# Altium schematic sheet p02_power.SchDoc
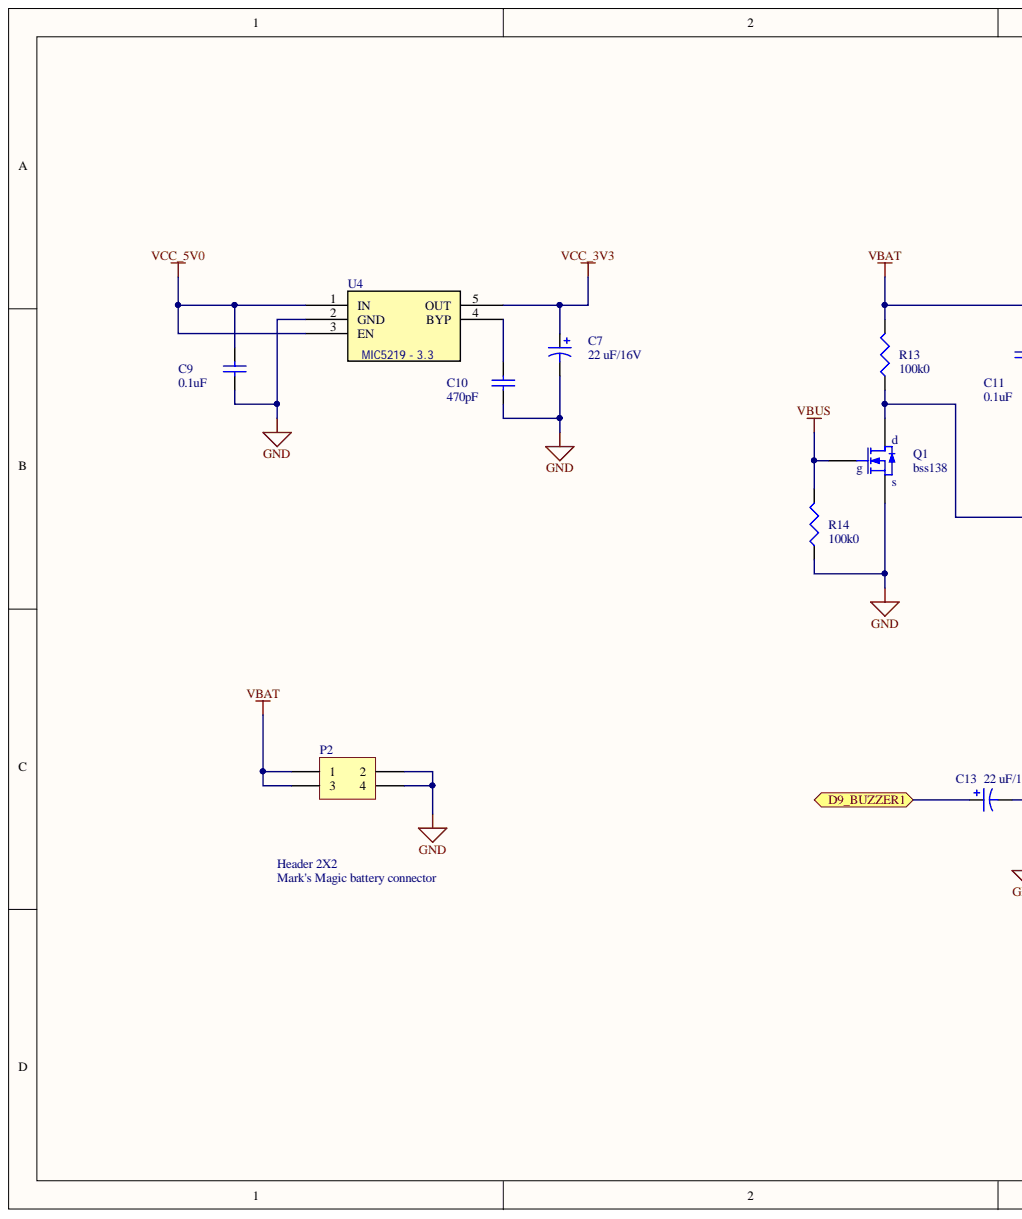
[diagram p0: p02_power.SchDoc, left]
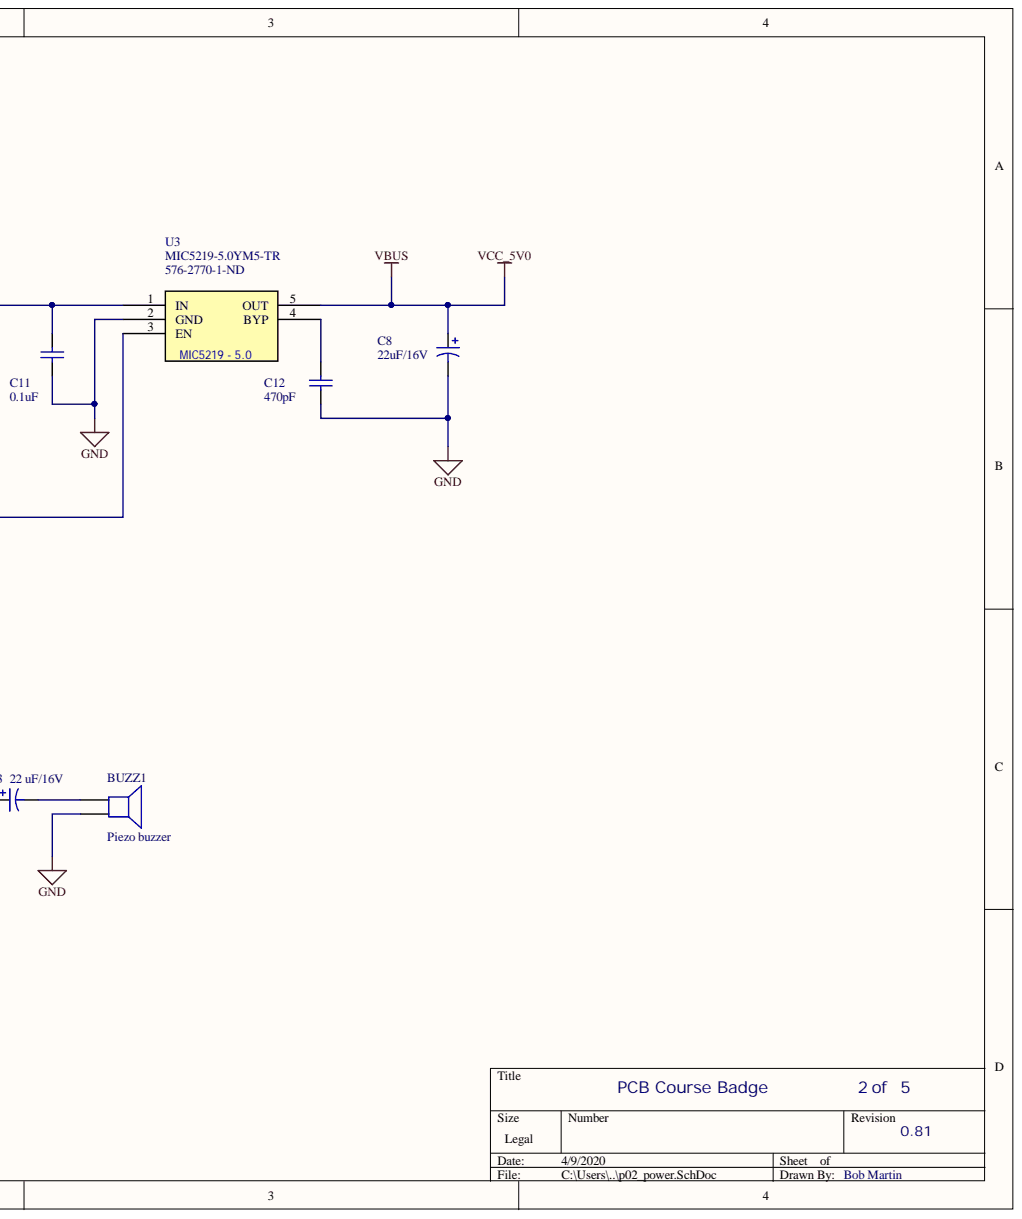
[diagram p1: p02_power.SchDoc, right]

## Parts
BUZZ1  Piezo buzzer  [PIN2]
C7  value 22 uF/16V  [C0805]  (hidden comment: Cap Pol3)
C8  value 22uF/16V  Detail: 22uF/16V  [C0805]  (hidden comment: Alum Electrolytic)
C9  value 0.1uF  [RAD-0.3]  (hidden comment: Cap)
C10  value 470pF  [RAD-0.3]  (hidden comment: Cap)
C11  value 0.1uF  [RAD-0.3]  (hidden comment: Cap)
C12  value 470pF  [RAD-0.3]  (hidden comment: Cap)
C13  value 22 uF/16V  Detail: 22 uF/16V  [C0805]  (hidden comment: Alum Electrolytic)
P2  Header 2X2  value Mark's Magic battery connector  [HDR2X2]
Q1  bss138  [2N7000-TO92_v2]
R13  value 100k0  [J1-0603]  (hidden comment: Res3)
R14  value 100k0  [J1-0603]  (hidden comment: Res3)
U3  Digi-Key PN: 576-2770-1-ND  MPN: MIC5219-5.0YM5-TR  [SOT-23-5_M5]  (hidden comment: Part Number: MIC5219-3.3YM5-TR)
U4  Part Number: MIC5219-3.3YM5-TR  [SOT-23-5_M5]  (value not drawn)

## Ports
D9_BUZZER1

## Nets
GND: BUZZ1.2 C7.2 C8.2 C9.1 C10.1 C11.1 C12.1 P2.2 P2.4 Q1.1 R14.1 U3.2(GND) U4.2(GND)
VBAT: C11.2 P2.1 P2.3 R13.2 U3.1(IN)
VBUS: C8.1 C9.2 Q1.2 R14.2 U3.5(OUT) U4.1(IN) U4.3(EN)
VCC_3V3: C7.1 U4.5(OUT)
D9_BUZZER1: C13.1 ; port D9_BUZZER1
NetBUZZ1_1: BUZZ1.1 C13.2
NetC10_2: C10.2 U4.4(BYP)
NetC12_2: C12.2 U3.4(BYP)
NetQ1_3: Q1.3 R13.1 U3.3(EN)

## Library data of the parts
BUZZ1 = Speaker: Loudspeaker; Manufacturer: PUI Audio, Inc.; MPN: 668-1448-ND; Detail: BUZZER ELEMENT STD 4.1KHZ 15MM; Component Kind: Standard; Digi-Key PN: 668-1448-ND; Library Name: Miscellaneous Devices.IntLib
C7 C8 C13 = Cap Pol3: Polarized Capacitor (Surface Mount); Manufacturer: KEMET; MPN: EDK226M016A9BAA; Component Kind: Standard; Digi-Key PN: 399-11437-1-ND; Library Name: Miscellaneous Devices.IntLib; Signal Integrity: Cap Pol; Simulation: CAP
  C7: Detail: 22 uF/16V
C9 C11 = Cap: Capacitor; Manufacturer: KEMET; MPN: C0603C104Z3VACTU; Detail: CAP CER 0.1UF 25V Y5V 0603; Component Kind: Standard; Digi-Key PN: 399-1100-1-ND; Library Name: Miscellaneous Devices.IntLib; Signal Integrity: Cap; Simulation: CAP
C10 C12 = Cap: Capacitor; Manufacturer: KEMET; MPN: C0603C471J1GACTU; Detail: CAP CER 470PF 100V C0G/NP0 0603; Component Kind: Standard; Digi-Key PN: 399-7921-1-ND; Library Name: Miscellaneous Devices.IntLib; Signal Integrity: Cap; Simulation: CAP
P2 = Header 2X2: Header, 2-Pin, Dual row; Manufacturer: Molex; MPN: 0015910060; Detail: CONN HEADER SMD 6POS 2.54MM; Component Kind: Standard; Digi-Key PN: WM17457-ND; Library Name: Miscellaneous Connectors.IntLib; Signal Integrity: Connector
Q1 = 2N7000: 2N7000 - TO92; Manufacturer: ON Semiconductor; Detail: MOSFET N-CH 50V 220MA SOT-23; Value: MOSFET N-CH 50V 220MA SOT-23; Component Kind: Standard; Digi-Key PN: BSS138CT-ND; Library Name: project_master.SchLib; MPN: BSS138; Simulation: NMOS
R13 R14 = Res3: Resistor; Manufacturer: Stackpole Electronics Inc; MPN: RMCF0603FT100K; Detail: RES 100K OHM 1% 1/10W 0603; Component Kind: Standard; Digi-Key PN: RMCF0603FT100KCT-ND; Library Name: Miscellaneous Devices.IntLib; Signal Integrity: Res; Simulation: RESISTOR
U3 = MIC5219-5.0YM: No Description Available; Manufacturer: Microchip Technology; Detail: IC REG LINEAR 5V 500MA SOT23-5; Value: MIC5219 5.0 LDO; Component Kind: Standard; Library Name: badge1.SCHLIB
U4 = MIC5219-3.3YM5-T: No Description Available; Manufacturer: Microchip Technology; Detail: IC REG LINEAR 3.3V 500MA SOT23-5; Value: MIC5219 3.3 LDO; Component Kind: Standard; Digi-Key PN: 576-1281-1-ND; Library Name: badge1.SCHLIB; MPN: MIC5219-3.3
